# Altium schematic sheet GPS.SchDoc
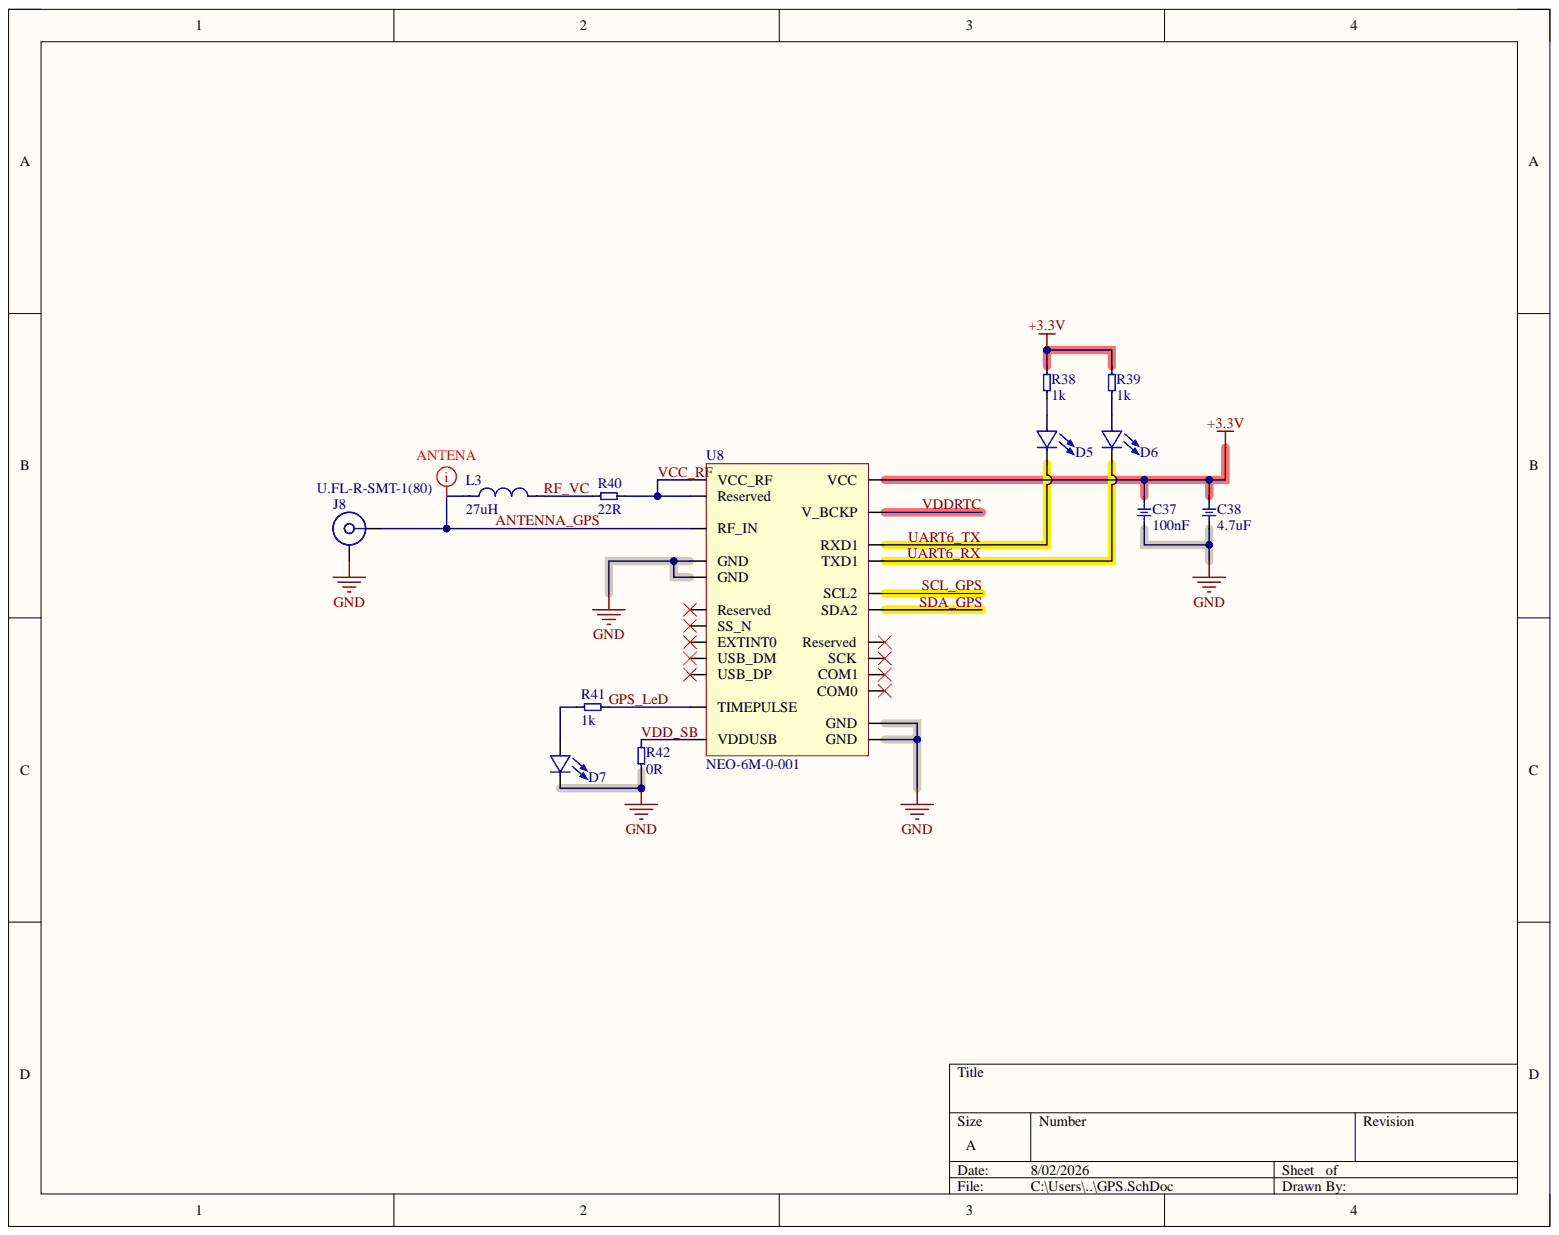
[diagram p0: GPS.SchDoc]

## Parts
C37  100nF  [CAP_0603]
C38  4.7uF  [CAP_0603]
D5  LED_0603  [LED_0603]  (value not drawn)
D6  LED_0603  [LED_0603]  (value not drawn)
D7  LED_0603  [LED_0603]  (value not drawn)
J8  U.FL-R-SMT-1(80)  [HIROSE_U.FL-R-SMT-1(80)]
L3  27uH  [IND_0805]
R38  1k  [RES_0603]
R39  1k  [RES_0603]
R40  22R  [RES_0603]
R41  1k  [RES_0603]
R42  0R  [RES_0603]
U8  NEO-6M-0-001  [NEO-6M-0-001]

## Nets
+3.3V: C37.2 C38.2 R38.1 R39.1 U8.23(VCC)
GND: C37.1 C38.1 D7.C J8.G1 R42.1 U8.10(GND) U8.12(GND) U8.13(GND) U8.24(GND)
ANTENNA_GPS: J8.SIG L3.1 U8.11(RF_IN)
GPS_LeD: R41.1 U8.3(TIMEPULSE)
RF_VC: L3.2 R40.1
SCL_GPS: U8.19(SCL2)
SDA_GPS: U8.18(SDA2)
UART6_RX: D6.C U8.20(TXD1)
UART6_TX: D5.C U8.21(RXD1)
VCC_RF: R40.2 U8.8(Reserved) U8.9(VCC_RF)
VDD_SB: R42.2 U8.7(VDDUSB)
VDDRTC: U8.22(V_BCKP)
NetD5_A: D5.A R38.2
NetD6_A: D6.A R39.2
NetD7_A: D7.A R41.2

Not connected: U8 1(Reserved) 2(SS_N) 4(EXTINT0) 5(USB_DM) 6(USB_DP) 14(COM0) 15(COM1) 16(SCK) 17(Reserved)

## Library data of the parts
C37 C38 = CAP_0603: Component Kind: Standard; Library Name: RQSchlib.SchLib
D5-D7 = LED_0603: LED SMD 0603 Ultra Yellow 593nm 70mcd Water Clear Lens 130 Deg Viewing Angle | Bivar Inc. SM0603UYC; Check_prices: https://www.snapeda.com/parts/SM0603UYC/Bivar/view-part/?ref=eda; Component Kind: Standard; MF: Bivar; MP: SM0603UYC; Package: 0603 Bivar; SnapEDA_Link: https://www.snapeda.com/parts/SM0603UYC/Bivar/view-part/?ref=snap; Library Name: RQSchlib.SchLib
L3 = IND_0805: MF: TDK; MP: MLG1005S2N0BT000; Check_prices: https://www.snapeda.com/parts/MLG1005S2N0BT000/TDK/view-part/?ref=eda; Component Kind: Standard; Digi-Key_Part_Number: 445-16277-2-ND; Package: 1005-2 TDK; Purchase-URL: https://pricing.snapeda.com/search?q=MLG1005S2N0BT000&ref=eda; SnapEDA_Link: https://www.snapeda.com/parts/MLG1005S2N0BT000/TDK/view-part/?ref=snap; Library Name: RQSchlib.SchLib
R38-R42 = RES_0603: Component Kind: Standard; Library Name: RQSchlib.SchLib
U8 = NEO-6M-0-001: GPSM-SMD_24P-L16.0-W12.2-P1.10 SMT ROHS; Component Kind: Standard; Library Name: RQSchlib.SchLib
J8 = U.FL-R-SMT-1(80): Availability: Unavailable; Component Kind: Standard; MP: U.FL-R-SMT-1(80); Package: None; Price: None; Library Name: RQSchlib.SchLib
